# Altium schematic sheet auto_turn.SchDoc(auto_turn)
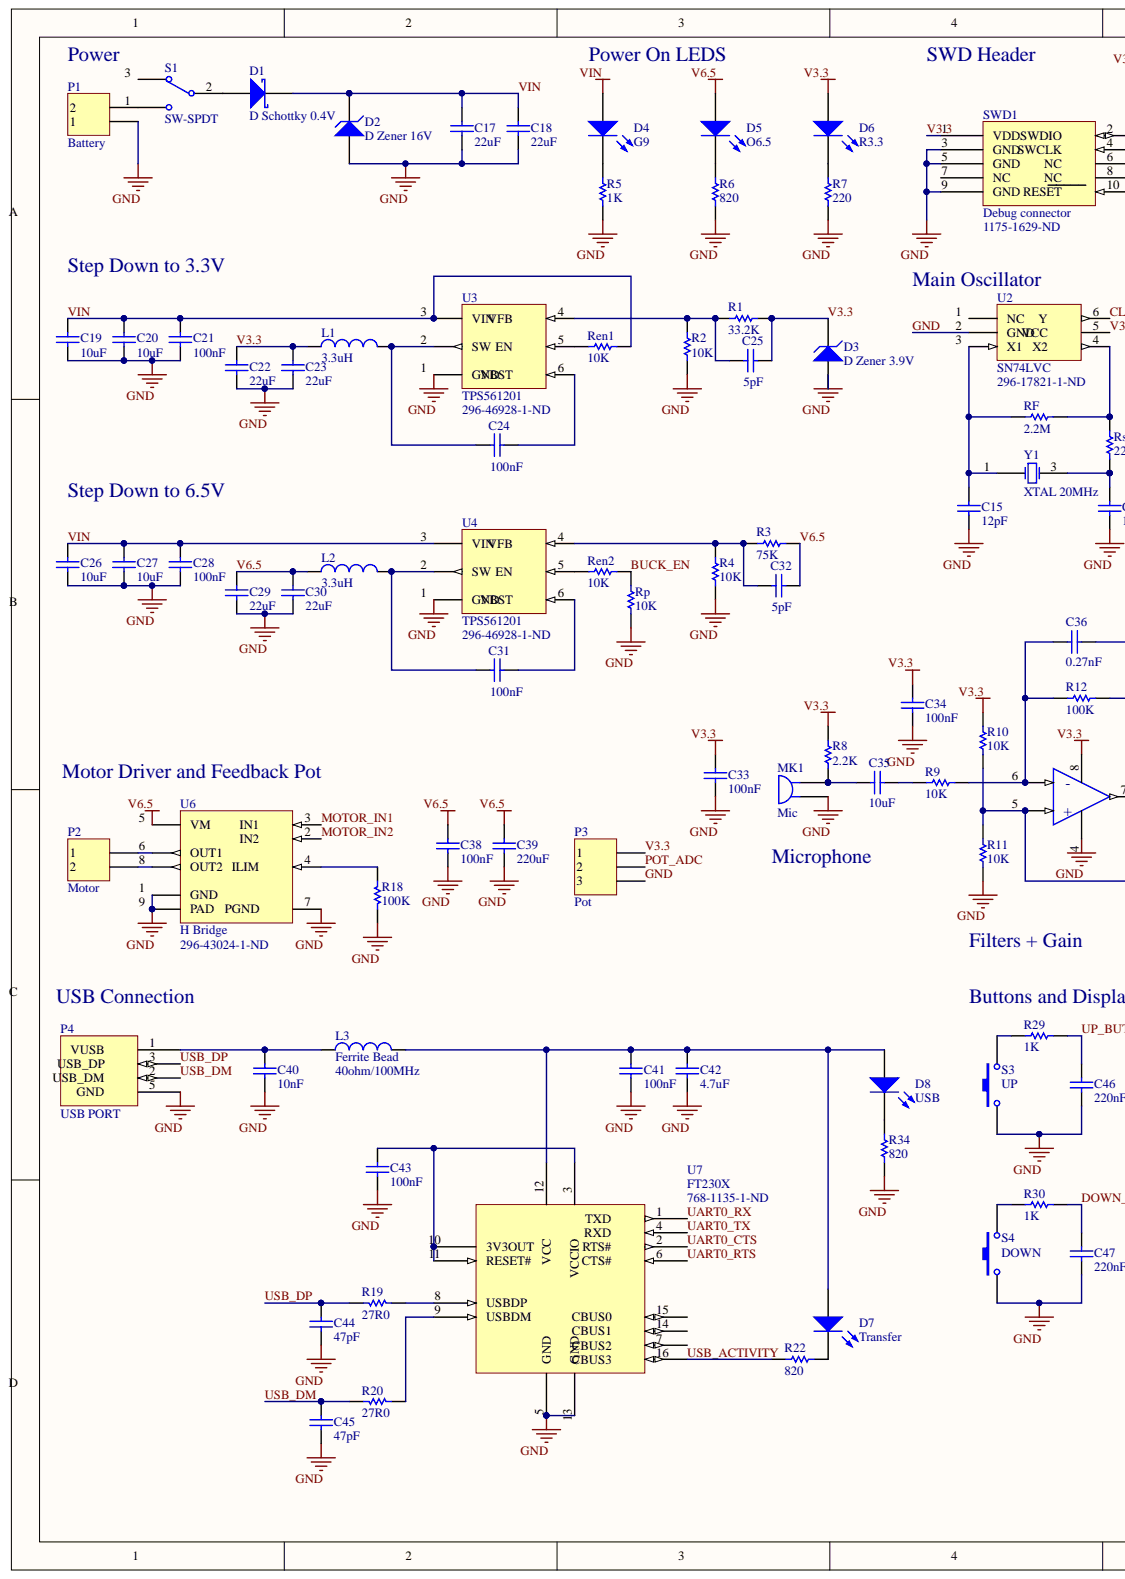
[diagram p0: auto_turn.SchDoc, left]
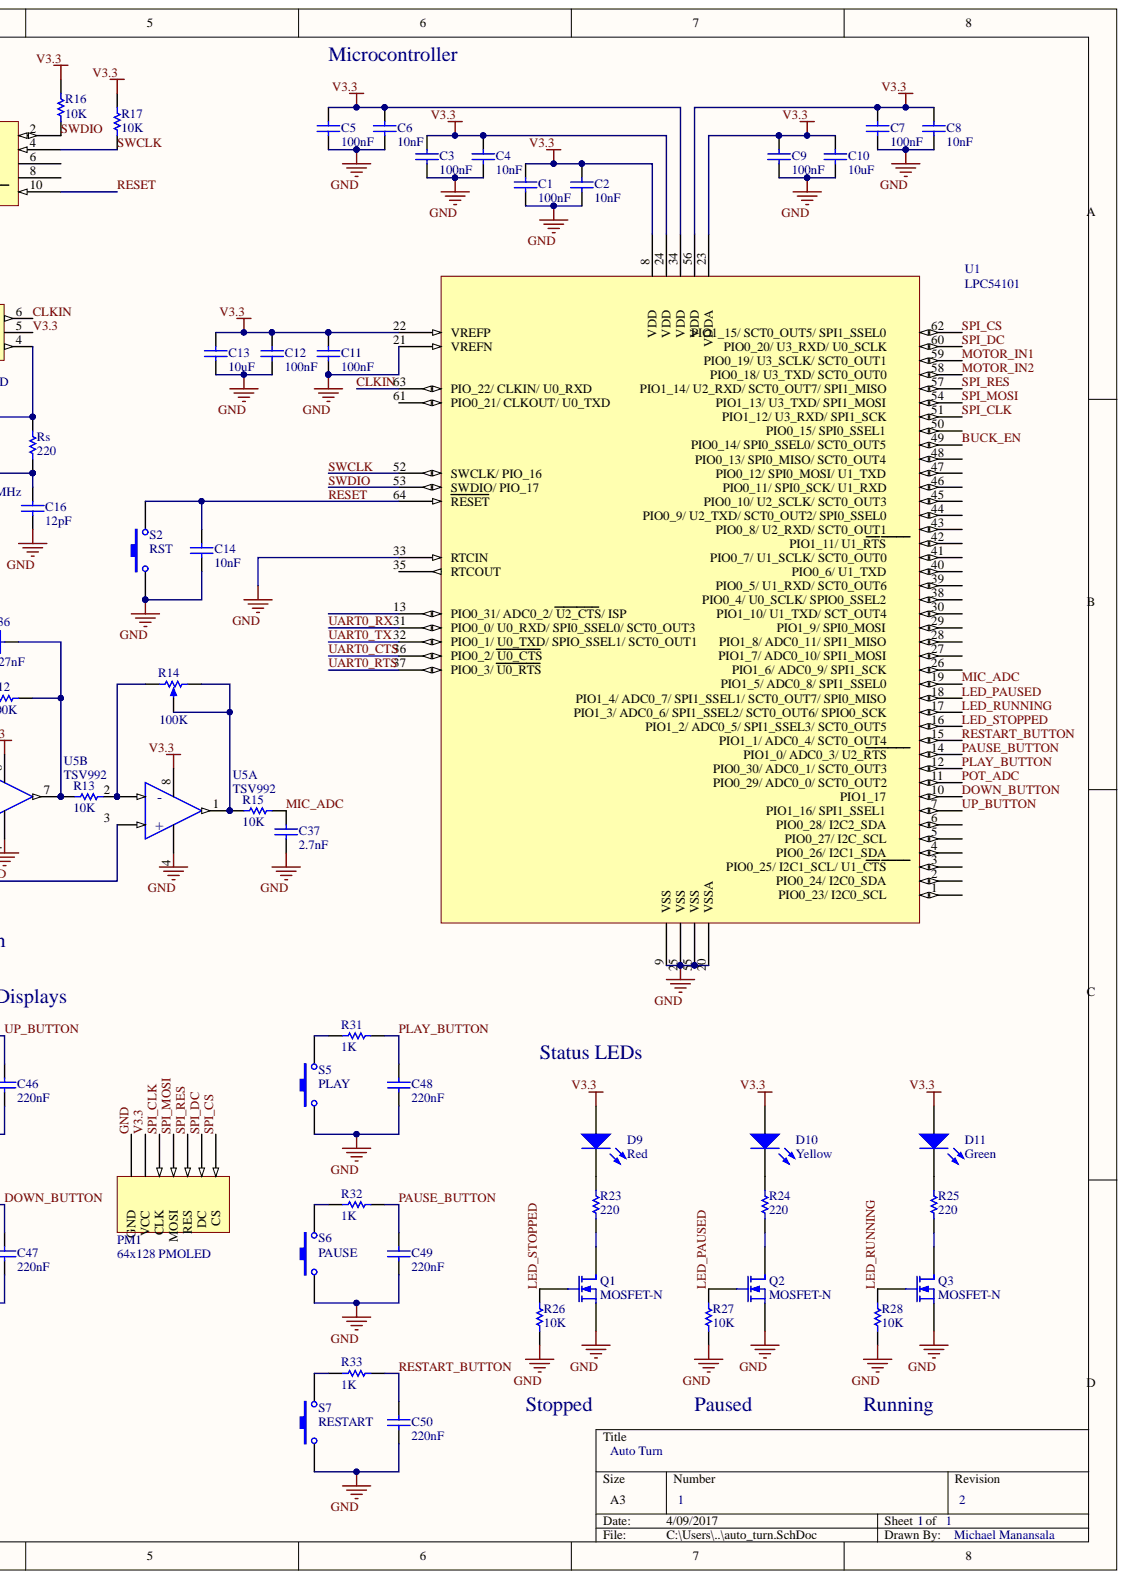
[diagram p1: auto_turn.SchDoc, right]

## Parts
C1  100nF  [0805 MD]
C2  10nF  [0805 MD]
C3  100nF  [0805 MD]
C4  10nF  [0805 MD]
C5  100nF  [0805 MD]
C6  10nF  [0805 MD]
C7  100nF  [0805 MD]
C8  10nF  [0805 MD]
C9  100nF  [0805 MD]
C10  10uF  [0805 MD]
C11  100nF  [0805 MD]
C12  100nF  [0805 MD]
C13  10uF  [0805 MD]
C14  10nF  [0805 MD]
C15  12pF  [0805 MD]
C16  12pF  [0805 MD]
C17  22uF  Voltage - Rated: 16V  [0805 MD]
C18  22uF  [0805 MD]
C19  10uF  [0805 MD]
C20  10uF  [0805 MD]
C21  100nF  [0805 MD]
C22  22uF  [0805 MD]
C23  22uF  [0805 MD]
C24  100nF  [0805 MD]
C25  5pF  [0805 MD]
C26  10uF  [0805 MD]
C27  10uF  [0805 MD]
C28  100nF  [0805 MD]
C29  22uF  [0805 MD]
C30  22uF  [0805 MD]
C31  100nF  [0805 MD]
C32  5pF  [0805 MD]
C33  100nF  [0805 MD]
C34  100nF  [0805 MD]
C35  10uF  [0805 MD]
C36  value 0.27nF  [0805 MD]  (hidden comment: 270pF)
C37  2.7nF  [0805 MD]
C38  100nF  [0805 MD]
C39  220uF  [RAD-0.1]
C40  10nF  [0805 MD]
C41  100nF  [0805 MD]
C42  4.7uF  [0805 MD]
C43  100nF  [0805 MD]
C44  47pF  [0805 MD]
C45  47pF  [0805 MD]
C46  220nF  [0805 MD]
C47  220nF  [0805 MD]
C48  220nF  [0805 MD]
C49  220nF  [0805 MD]
C50  220nF  [0805 MD]
D1  D Schottky 0.4V  [DO219AB]
D2  D Zener 16V  [DO219AB]
D3  D Zener 3.9V  [DO219AB]
D4  G9  [0805 MD]
D5  O6.5  [0805 MD]
D6  R3.3  [0805 MD]
D7  Transfer  [0805 MD]
D8  USB  [0805 MD]
D9  Red  [5mm LED]
D10  Yellow  [5mm LED]
D11  Green  [5mm LED]
L1  3.3uH  [0805 MD]
L2  3.3uH  [0805 MD]
L3  Ferrite Bead  value 40ohm/100MHz  [0805 MD]
MK1  Mic  [CMA-4544PF-W]
P1  Battery  [HDR1X2]
P2  Motor  [HDR1X2]
P3  Pot  [HDR1X3]
P4  USB PORT  [USB Micro B]
PM1  64x128 PMOLED  [PMOLED 128x64]
Q1  MOSFET-N  [SOT23]
Q2  MOSFET-N  [SOT23]
Q3  MOSFET-N  [SOT23]
R1  33.2K  [0805 MD]
R2  10K  [0805 MD]
R3  75K  [0805 MD]
R4  10K  [0805 MD]
R5  1K  [0805 MD]
R6  820  [0805 MD]
R7  220  [0805 MD]
R8  2.2K  [0805 MD]
R9  10K  [0805 MD]
R10  10K  [0805 MD]
R11  10K  [0805 MD]
R12  100K  [0805 MD]
R13  10K  [0805 MD]
R14  100K  [Trimmer 23B]
R15  10K  [0805 MD]
R16  10K  [0805 MD]
R17  10K  [0805 MD]
R18  100K  [0805 MD]
R19  27R0  [0805 MD]
R20  27R0  [0805 MD]
R22  820  [0805 MD]
R23  220  [0805 MD]
R24  220  [0805 MD]
R25  220  [0805 MD]
R26  10K  [0805 MD]
R27  10K  [0805 MD]
R28  10K  [0805 MD]
R29  1K  [0805 MD]
R30  1K  [0805 MD]
R31  1K  [0805 MD]
R32  1K  [0805 MD]
R33  1K  [0805 MD]
R34  820  [0805 MD]
Ren1  10K  [0805 MD]
Ren2  10K  [0805 MD]
RF  2.2M  [0805 MD]
Rp  10K  [0805 MD]
Rs  220  [0805 MD]
S1  SW-SPDT  [MFS101D-8-Z]
S2  RST  [Pushbutton KMR2]
S3  UP  [Pushbutton KMR2]
S4  DOWN  [Pushbutton KMR2]
S5  PLAY  [Pushbutton KMR2]
S6  PAUSE  [Pushbutton KMR2]
S7  RESTART  [Pushbutton KMR2]
SWD1  Debug connector  Supplier Part Number 1: 1175-1629-ND  [ARM SWD connector]
U1  LPC54101  [LQFP64]
U2  SN74LVC  [SOT236]
U3  TPS561201  [SOT236]
U4  TPS561201  [SOT236]
U5  TSV992  [SOIC-8]  (parts A,B)
U6  H Bridge  Supplier Part Number 1: 296-43024-1-ND  [SOIC-8 PP]
U7  FT230X  Supplier Part Number 1: 768-1135-1-ND  [SSOP-16 FTDI]
Y1  XTAL 20MHz  [SMD XTAL 4Pin]

## Nets
BUCK_EN: Ren2.2 Rp.2 U1.49
CLKIN: U1.63(PIO_22/_CLKIN/_U0_RXD) U2.6
DOWN_BUTTON: C47.2 R30.1 U1.10
GND: C1.1 C2.1 C3.1 C4.1 C5.1 C6.1 C7.1 C8.1 C9.1 C10.1 C11.1 C12.1 C13.1 C14.1 C15.1 C16.1 C17.1 C18.1 C19.1 C20.1 C21.1 C22.1 C23.1 C26.1 C27.1 C28.1 C29.1 C30.1 C33.1 C34.1 C37.1 C38.1 C39.1 C40.1 C41.1 C42.1 C43.1 C44.1 C45.1 C46.1 C47.1 C48.1 C49.1 C50.1 D2.1 D3.1 MK1.1 P1.1 P3.3 P4.5 PM1.1 Q1.3 Q2.3 Q3.3 R2.1 R4.1 R5.2 R6.1 R7.1 R11.1 R18.1 R26.1 R27.1 R28.1 R34.1 Rp.1 S2.1 S3.1 S4.1 S5.1 S6.1 S7.1 SWD1.3(GND) SWD1.5(GND) SWD1.9 U1.9(VSS) U1.20(VSSA) U1.21(VREFN) U1.25(VSS) U1.33(RTCIN) U1.55(VSS) U2.2(GND) U3.1(GND) U4.1(GND) U5.4 U6.1(GND) U6.7 U6.9(PAD) U7.5(GND) U7.13(GND) Y1.2 Y1.4
LED_PAUSED: Q2.2 R27.2 U1.18
LED_RUNNING: Q3.2 R28.2 U1.17
LED_STOPPED: Q1.2 R26.2 U1.16
MIC_ADC: C37.2 R15.2 U1.19
MOTOR_IN1: U1.59 U6.3
MOTOR_IN2: U1.58 U6.2
PAUSE_BUTTON: C49.2 R32.1 U1.14
PLAY_BUTTON: C48.2 R31.1 U1.12
POT_ADC: P3.2 U1.11
RESET: C14.2 S2.2 SWD1.10 U1.64(RESET)
RESTART_BUTTON: C50.2 R33.1 U1.15
SPI_CLK: PM1.3 U1.51
SPI_CS: PM1.7 U1.62
SPI_DC: PM1.6 U1.60
SPI_MOSI: PM1.4 U1.54
SPI_RES: PM1.5 U1.57
SWCLK: R17.1 SWD1.4 U1.52(SWCLK/_PIO_16)
SWDIO: R16.1 SWD1.2 U1.53(SWDIO/_PIO_17)
UART0_CTS: U1.36 U7.2
UART0_RTS: U1.37 U7.6
UART0_RX: U1.31 U7.1
UART0_TX: U1.32 U7.4
UP_BUTTON: C46.2 R29.1 U1.7
USB_ACTIVITY: R22.2 U7.16
USB_DM: C45.2 P4.2 R20.2
USB_DP: C44.2 P4.3 R19.2
V3.3: C1.2 C2.2 C3.2 C4.2 C5.2 C6.2 C7.2 C8.2 C9.2 C10.2 C11.2 C12.2 C13.2 C22.2 C23.2 C25.1 C33.2 C34.2 D3.2 D6.1 D9.1 D10.1 D11.1 L1.1 P3.1 PM1.2 R1.2 R8.2 R10.2 R16.2 R17.2 SWD1.1 U1.8 U1.22(VREFP) U1.23 U1.24 U1.34 U1.56 U2.5 U5.8
V6.5: C29.2 C30.2 C32.1 C38.2 C39.2 D5.1 L2.1 R3.2 U6.5(VM)
VIN: C17.2 C18.2 C19.2 C20.2 C21.2 C26.2 C27.2 C28.2 D1.2 D2.2 D4.1 Ren1.2 U3.3(VIN) U4.3(VIN)
NetC15_2: C15.2 RF.1 U2.3(X1) Y1.1
NetC16_2: C16.2 Rs.1 Y1.3
NetC24_1: C24.1 U3.6
NetC24_2: C24.2 L1.2 U3.2
NetC25_2: C25.2 R1.1 R2.2 U3.4
NetC31_1: C31.1 U4.6
NetC31_2: C31.2 L2.2 U4.2
NetC32_2: C32.2 R3.1 R4.2 U4.4
NetC35_1: C35.1 R9.1
NetC35_2: C35.2 MK1.2 R8.1
NetC36_1: C36.1 R12.2 R13.1 U5.7
NetC36_2: C36.2 R9.2 R12.1 U5.6(-)
NetC40_2: C40.2 L3.1 P4.1
NetC41_2: C41.2 C42.2 D7.1 D8.1 L3.2 U7.12
NetC43_2: C43.2 U7.3 U7.10(3V3OUT) U7.11(RESET#)
NetD1_1: D1.1 S1.2
NetD4_2: D4.2 R5.1
NetD5_2: D5.2 R6.2
NetD6_2: D6.2 R7.2
NetD7_2: D7.2 R22.1
NetD8_2: D8.2 R34.2
NetD9_2: D9.2 R23.2
NetD10_2: D10.2 R24.2
NetD11_2: D11.2 R25.2
NetP1_2: P1.2 S1.1
NetP2_1: P2.1 U6.6(OUT1)
NetP2_2: P2.2 U6.8(OUT2)
NetQ1_1: Q1.1 R23.1
NetQ2_1: Q2.1 R24.1
NetQ3_1: Q3.1 R25.1
NetR10_1: R10.1 R11.2 U5.3(+) U5.5(+)
NetR13_2: R13.2 R14.1 U5.2(-)
NetR14_2: R14.2 R14.3 R15.1 U5.1
NetR18_2: R18.2 U6.4
NetR19_1: R19.1 U7.8(USBDP)
NetR20_1: R20.1 U7.9(USBDM)
NetR29_2: R29.2 S3.2
NetR30_2: R30.2 S4.2
NetR31_2: R31.2 S5.2
NetR32_2: R32.2 S6.2
NetR33_2: R33.2 S7.2
NetRen1_1: Ren1.1 U3.5
NetRen2_1: Ren2.1 U4.5
NetRF_2: RF.2 Rs.2 U2.4

Not connected: S1 3; SWD1 6 7(NC) 8; U1 1 2 3 4 5 6 13 26 27 28 29 30 35(RTCOUT) 38 39 40 41 42 43 44 45 46 47 48 50 61; U2 1(NC); U7 7 14 15

## Library data of the parts
C1 C3 C5 C7 C9 C11 C12 C21 C24 C28 C31 C33 C34 C38 C41 C43 = Cap: Capacitor; Manufacturer: Samsung Electro-Mechanics America, Inc.; ManufacturerPartNumber: CL21B104JBCNNNC; Voltage - Rated: 50V; Component Kind: Standard; Library Name: Miscellaneous Devices.IntLib; Supplier 1: Digi-Key; Supplier Part Number 1: 1276-1090-1-ND; Value: 100nF
C2 C4 C6 C8 C14 C40 = Cap: Capacitor; Manufacturer: Samsung Electro-Mechanics America, Inc.; ManufacturerPartNumber: CL21B103JBANNNC; Voltage - Rated: 50V; Component Kind: Standard; Library Name: Miscellaneous Devices.IntLib; Supplier 1: Digi-Key; Supplier Part Number 1: 1276-1245-1-ND; Value: 10nF
C10 C13 C19 C20 C26 C27 C35 = Cap: Capacitor; Manufacturer: Samsung Electro-Mechanics America, Inc.; ManufacturerPartNumber: CL21A106KOCLRNC; Voltage - Rated: 16V; Component Kind: Standard; Library Name: Miscellaneous Devices.IntLib; Supplier 1: Digi-Key; Supplier Part Number 1: 1276-2399-1-ND; Value: 10uF
C15 C16 = Cap: Capacitor; Manufacturer: Samsung Electro-Mechanics America, Inc.; ManufacturerPartNumber: CL21C120JBANNNC; Voltage - Rated: 50V; Component Kind: Standard; Library Name: Miscellaneous Devices.IntLib; Supplier 1: Digi-Key; Supplier Part Number 1: 1276-1120-1-ND; Value: 12pF
C17 = Cap: Capacitor; Manufacturer: Samsung Electro-Mechanics America, Inc.; ManufacturerPartNumber: CL21A226MOCLRNC; Component Kind: Standard; Library Name: Miscellaneous Devices.IntLib; Supplier 1: Digi-Key; Supplier Part Number 1: 1276-6780-1-ND; Value: 22uF
C18 C22 C23 C29 C30 = Cap: Capacitor; Manufacturer: Samsung Electro-Mechanics America, Inc.; ManufacturerPartNumber: CL21A226MOCLRNC; Voltage - Rated: 16V; Component Kind: Standard; Library Name: Miscellaneous Devices.IntLib; Supplier 1: Digi-Key; Supplier Part Number 1: 1276-6780-1-ND; Value: 22uF
C25 C32 = Cap: Capacitor; Manufacturer: Samsung Electro-Mechanics America, Inc.; ManufacturerPartNumber: CL21C050CBANNNC; Voltage - Rated: 50V; Component Kind: Standard; Library Name: Miscellaneous Devices.IntLib; Supplier 1: Digi-Key; Supplier Part Number 1: 1276-2552-1-ND; Value: 5pF
C36 = Cap: Capacitor; Manufacturer: Samsung Electro-Mechanics America, Inc.; ManufacturerPartNumber: CL21B271KCANNNC; Voltage - Rated: 100V; Component Kind: Standard; Library Name: Miscellaneous Devices.IntLib; Supplier 1: Digi-Key; Supplier Part Number 1: 1276-2494-1-ND
C37 = Cap: Capacitor; Manufacturer: Samsung Electro-Mechanics America, Inc.; ManufacturerPartNumber: CL21C272JBFNNNE; Voltage - Rated: 50V; Component Kind: Standard; Library Name: Miscellaneous Devices.IntLib; Supplier 1: Digi-Key; Supplier Part Number 1: 1276-6527-1-ND; Value: 2.7nF
C39 = Cap: Capacitor; Manufacturer: Nichicon; ManufacturerPartNumber: UVR1C221MED; Voltage - Rated: 16V; Component Kind: Standard; Library Name: Miscellaneous Devices.IntLib; Supplier 1: Digi-Key; Supplier Part Number 1: 493-1041-ND; Value: 220uF
C42 = Cap: Capacitor; Manufacturer: Samsung Electro-Mechanics America, Inc.; ManufacturerPartNumber: CL21A475KQFNNNG; Voltage - Rated: 6.3V; Component Kind: Standard; Library Name: Miscellaneous Devices.IntLib; Supplier 1: Digi-Key; Supplier Part Number 1: 1276-6465-1-ND; Value: 4.7uF
C44 C45 = Cap: Capacitor; Manufacturer: Samsung Electro-Mechanics America, Inc.; ManufacturerPartNumber: CL21C470JBANNNC; Voltage - Rated: 50V; Component Kind: Standard; Library Name: Miscellaneous Devices.IntLib; Supplier 1: Digi-Key; Supplier Part Number 1: 1276-1156-1-ND; Value: 47pF
C46-C50 = Cap: Capacitor; Manufacturer: Samsung Electro-Mechanics America, Inc.; ManufacturerPartNumber: CL21B224KAFNNNG; Voltage - Rated: 15V; Component Kind: Standard; Library Name: Miscellaneous Devices.IntLib; Supplier 1: Digi-Key; Supplier Part Number 1: 1276-6477-1-ND; Value: 220nF
D1 = D Schottky: Schottky Diode; Manufacturer: Vishay Semiconductor Diodes Division; ManufacturerPartNumber: SL02-GS08; Component Kind: Standard; Library Name: Miscellaneous Devices.IntLib; Supplier 1: Digi-Key; Supplier Part Number 1: SL02-GS08CT-ND
D2 = D Zener: Zener Diode; Manufacturer: Vishay Semiconductor Diodes Division; ManufacturerPartNumber: BZD27C16P-E3-08; Component Kind: Standard; Library Name: Miscellaneous Devices.IntLib; Supplier 1: Digi-Key; Supplier Part Number 1: BZD27C16P-E3-08GICT-ND
D3 = D Zener: Zener Diode; Manufacturer: Vishay Semiconductor Diodes Division; ManufacturerPartNumber: BZD27B3V9P-M3-08; Component Kind: Standard; Library Name: Miscellaneous Devices.IntLib; Supplier 1: Digi-Key; Supplier Part Number 1: BZD27B3V9P-M3-08GICT-ND
D4 D8 = LED1: LED Green; Manufacturer: Lite-On Inc.; ManufacturerPartNumber: LTST-C171KGKT; Component Kind: Standard; Library Name: Miscellaneous Devices.IntLib; Supplier 1: Digi-Key; Supplier Part Number 1: 160-1426-1-ND
D5 = LED1: LED Orange; Manufacturer: Lite-On Inc.; ManufacturerPartNumber: LTST-C170KFKT; Component Kind: Standard; Library Name: Miscellaneous Devices.IntLib; Supplier 1: Digi-Key; Supplier Part Number 1: 160-1413-1-ND
D6 = LED1: LED Red; Manufacturer: Lite-On Inc.; ManufacturerPartNumber: LTST-C171KRKT; Component Kind: Standard; Library Name: Miscellaneous Devices.IntLib; Supplier 1: Digi-Key; Supplier Part Number 1: 160-1427-1-ND
D7 = LED1: Green LED; Manufacturer: Lite-On Inc.; ManufacturerPartNumber: LTST-C171KRKT; Component Kind: Standard; Library Name: Miscellaneous Devices.IntLib; Supplier 1: Digi-Key; Supplier Part Number 1: 160-1427-1-ND
D9 = LED1: LED Red; Manufacturer: Kingbright; ManufacturerPartNumber: WP7113ID; Component Kind: Standard; Library Name: Miscellaneous Devices.IntLib; Supplier 1: Digi-Key; Supplier Part Number 1: 754-1264-ND
D10 = LED1: LED Yellow; Manufacturer: Kingbright; ManufacturerPartNumber: WP7113ND; Component Kind: Standard; Library Name: Miscellaneous Devices.IntLib; Supplier 1: Digi-Key; Supplier Part Number 1: 754-1896-ND
D11 = LED1: LED Green; Manufacturer: Kingbright; ManufacturerPartNumber: WP7113GD; Component Kind: Standard; Library Name: Miscellaneous Devices.IntLib; Supplier 1: Digi-Key; Supplier Part Number 1: 754-1263-ND
L1 L2 = Inductor: Manufacturer: Taiyo Yuden; ManufacturerPartNumber: BRC2012T3R3MD; Component Kind: Standard; Description: Inductor; Library Name: Miscellaneous Devices.IntLib; Supplier 1: Digi-Key; Supplier Part Number 1: 587-2906-1-ND; Value: 3.3uH
L3 = Inductor: Manufacturer: Laird-Signal Integrity Products; ManufacturerPartNumber: MI0805K400R-10; Component Kind: Standard; Description: Inductor; Library Name: Miscellaneous Devices.IntLib; Supplier 1: Digi-Key; Supplier Part Number 1: 240-2389-1-ND
MK1 = Mic1: Electret Microphone; Manufacturer: CUI Inc.; Component Kind: Standard; Library Name: Miscellaneous Devices.IntLib; ManufacturerPartNumber: CMA-4544PF-W; Supplier 1: Digi-Key; Supplier Part Number 1: 102-1721-ND
P1 = Header 2: Header, 2-Pin; Component Kind: Standard; Library Name: Miscellaneous Connectors.IntLib
P2 = Header 2: Header, 2-Pin; Manufacturer: DFRobot; ManufacturerPartNumber: FIT0495-E; Speed: 73 RPM; Component Kind: Standard; Library Name: Miscellaneous Connectors.IntLib; Supplier 1: Digi-Key; Supplier Part Number 1: 1738-1283-ND
P3 = Header 3: Header, 3-Pin; Manufacturer: Bourns Inc.; ManufacturerPartNumber: PDB241-E420P-103B0; Value: 10K; Component Kind: Standard; Library Name: Miscellaneous Connectors.IntLib; Supplier 1: Digi-Key; Supplier Part Number 1: PDB241-E420P-103B0-ND
P4 = USB PORT: Manufacturer: Amphenol FCI; ManufacturerPartNumber: 10118192-0001LF; Component Kind: Standard; Description: USB port; Supplier 1: Digi-Key; Supplier Part Number 1: 609-4613-1-ND; Library Name: auto_turn_components.SchLib
PM1 = PMOLED: Passive Matrix Organic LED Display; Component Kind: Standard; Library Name: auto_turn_components.SchLib
Q1-Q3 = MOSFET-N: DMN2005K-7DICT-ND; Manufacturer: Diodes Incorporated; Component Kind: Standard; Library Name: Miscellaneous Devices.IntLib; ManufacturerPartNumber: DMN2005K-7; Supplier 1: Digi-Key; Supplier Part Number 1: DMN2005K-7DICT-ND
R1 = Res1: Resistor; Manufacturer: Stackpole Electronics; ManufacturerPartNumber: RMCF0805FT33K2; Component Kind: Standard; Library Name: Miscellaneous Devices.IntLib; Supplier 1: Digi-Key; Supplier Part Number 1: RMCF0805FT33K2CT-ND; Value: 33.2K
R2 R4 R9-R11 R13 R15-R17 R26-R28 Ren1 Ren2 Rp = Res1: Resistor; Manufacturer: Stackpole Electronics; ManufacturerPartNumber: RMCF0805FT10K0; Component Kind: Standard; Library Name: Miscellaneous Devices.IntLib; Supplier 1: Digi-Key; Supplier Part Number 1: RMCF0805FT10K0CT-ND; Value: 10K
R3 = Res1: Resistor; Manufacturer: Stackpole Electronics; ManufacturerPartNumber: RMCF0805FT75K0; Component Kind: Standard; Library Name: Miscellaneous Devices.IntLib; Supplier 1: Digi-Key; Supplier Part Number 1: RMCF0805FT75K0CT-ND; Value: 75K
R5 R29-R33 = Res1: Resistor; Manufacturer: Stackpole Electronics; ManufacturerPartNumber: RMCF0805FT1K00; Component Kind: Standard; Library Name: Miscellaneous Devices.IntLib; Supplier 1: Digi-Key; Supplier Part Number 1: RMCF0805FT1K00CT-ND; Value: 1K
R6 R22 R34 = Res1: Resistor; Manufacturer: Stackpole Electronics; ManufacturerPartNumber: RMCF0805FT820R; Component Kind: Standard; Library Name: Miscellaneous Devices.IntLib; Supplier 1: Digi-Key; Supplier Part Number 1: RMCF0805FT820RCT-ND; Value: 820
R7 R23-R25 Rs = Res1: Resistor; Manufacturer: Stackpole Electronics; ManufacturerPartNumber: RMCF0805FT220R; Component Kind: Standard; Library Name: Miscellaneous Devices.IntLib; Supplier 1: Digi-Key; Supplier Part Number 1: RMCF0805FT220RCT-ND; Value: 220
R8 = Res1: Resistor; Manufacturer: Stackpole Electronics; ManufacturerPartNumber: RMCF0805FT2K20; Component Kind: Standard; Library Name: Miscellaneous Devices.IntLib; Supplier 1: Digi-Key; Supplier Part Number 1: RMCF0805FT2K20CT-ND; Value: 2.2K
R12 R18 = Res1: Resistor; Manufacturer: Stackpole Electronics; ManufacturerPartNumber: RMCF0805FT100K; Component Kind: Standard; Library Name: Miscellaneous Devices.IntLib; Supplier 1: Digi-Key; Supplier Part Number 1: RMCF0805FT100KCT-ND; Value: 100K
R14 = RPot: Potentiometer; Manufacturer: TT Electronics/BI; ManufacturerPartNumber: 23BR100KLFTR; Component Kind: Standard; Library Name: Miscellaneous Devices.IntLib; Supplier 1: Digi-Key; Supplier Part Number 1: 987-1013-1-ND; Value: 100K
R19 R20 = Res1: Resistor; Manufacturer: Stackpole Electronics; ManufacturerPartNumber: RMCF0805FT27R0; Component Kind: Standard; Library Name: Miscellaneous Devices.IntLib; Supplier 1: Digi-Key; Supplier Part Number 1: RMCF0805FT27R0CT-ND; Value: 27R0
RF = Res1: Resistor; Manufacturer: Stackpole Electronics; ManufacturerPartNumber: RMCF0805JT2M20; Component Kind: Standard; Library Name: Miscellaneous Devices.IntLib; Supplier 1: Digi-Key; Supplier Part Number 1: RMCF0805JT2M20CT-ND; Value: 2.2M
S1 = SW-SPDT: Manufacturer: Copal Electronics Inc.; Component Kind: Standard; Description: SW-SPDT; Library Name: Miscellaneous Devices.IntLib; ManufacturerPartNumber: MFS101D-8-Z; Supplier 1: Digi-Key; Supplier Part Number 1: 563-1556-ND
S2-S7 = SW-PB: Switch; Manufacturer: C&K; ManufacturerPartNumber: KMR231NG LFS; Component Kind: Standard; Library Name: Miscellaneous Devices.IntLib; Supplier 1: Digi-Key; Supplier Part Number 1: CKN10246CT-ND
SWD1 = Debug connector: ARM 10 pin SWD connecttor; Manufacturer: CNC Tech; ManufacturerPartNumber: 3220-10-0300-00; Component Kind: Standard; Supplier 1: Digi-Key; Library Name: auto_turn_components.SchLib
U1 = LPC54101: LPC54101 uC 64 pin; Manufacturer: NXP; ManufacturerPartNumber: LPC54101J256BD64QL; Component Kind: Standard; Supplier 1: DigiKey; Supplier Part Number 1: 568-12886-ND; Library Name: auto_turn_components.SchLib
U2 = SN74LVC1GX04: Crystal Oscillator Driver IC SOT-23-6; Manufacturer: Texas Instruments; ManufacturerPartNumber: SN74LVC1GX04DBVR; Component Kind: Standard; Supplier 1: Digi-Key; Supplier Part Number 1: 296-17821-1-ND; Library Name: auto_turn_components.SchLib
U3 U4 = TPS561201: Buck Regulator; Manufacturer: Texas Instruments; ManufacturerPartNumber: TPS561201DDCT; Component Kind: Standard; Supplier 1: Digi-Key; Supplier Part Number 1: 296-46928-1-ND; Library Name: auto_turn_components.SchLib
U5 = TSV992: Dual Op Amp; Manufacturer: ST Electronics; ManufacturerPartNumber: TSV992IYDT; Component Kind: Standard; Supplier 1: DigiKey; Supplier Part Number 1: 497-10359-1-ND; Library Name: auto_turn_components.SchLib
U6 = DRV8871: Half Bridge DC Motor Driver; Manufacturer: Texas Instruments; ManufacturerPartNumber: DRV8871DDAR; Component Kind: Standard; Supplier 1: Digi-Key; Library Name: auto_turn_components.SchLib
U7 = FT230X: FT230X USB TO BASIC UART IC; Manufacturer: FTDI; Component Kind: Standard; ManufacturerPartNumber: FT230X; Supplier 1: Digi-Key; Library Name: auto_turn_components.SchLib
Y1 = XTAL: Crystal Oscillator; Manufacturer: Abracon LLC; ManufacturerPartNumber: ABM3B-20.000MHZ-10-1-U-T; Component Kind: Standard; Library Name: Miscellaneous Devices.IntLib; Supplier 1: Digi-Key; Supplier Part Number 1: 300-8214-1-ND
